# Altium schematic sheet FPGA_MISC.SchDoc(FPGA_MISC)
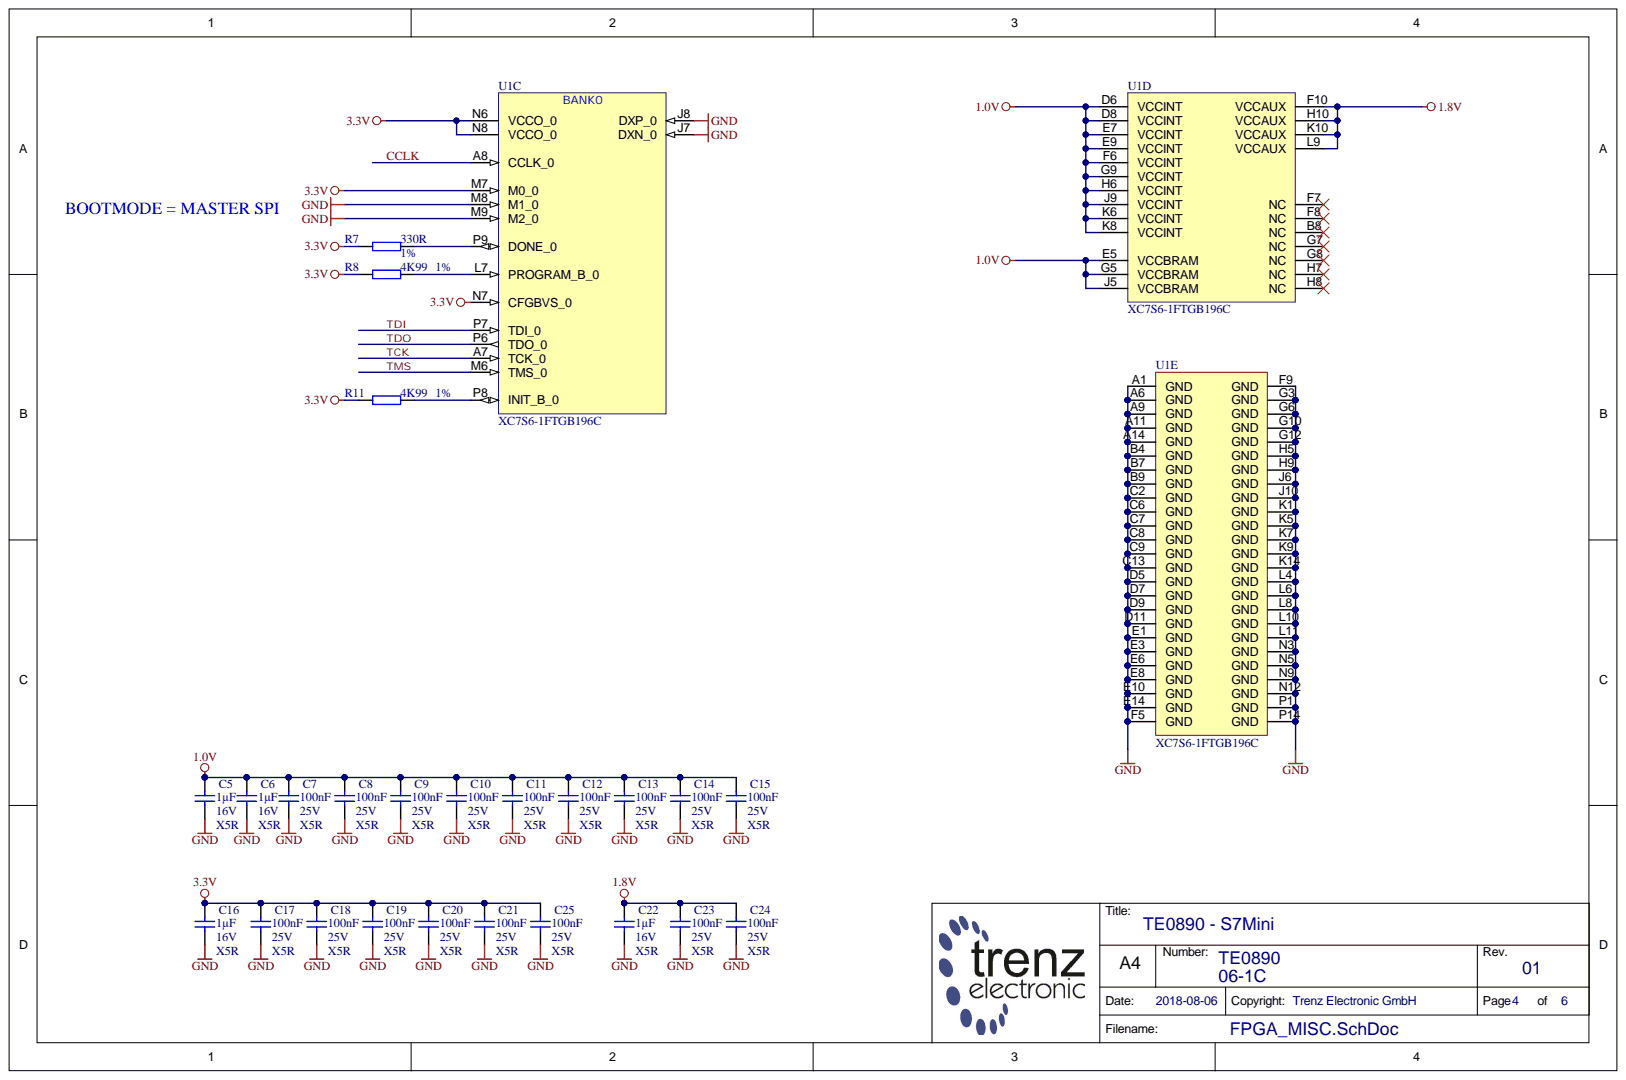
[diagram p0: FPGA_MISC.SchDoc]

## Parts
C5  1µF  Technology: X5R  Voltage: 16V  [CAPC1005X55N]
C6  1µF  Technology: X5R  Voltage: 16V  [CAPC1005X55N]
C7  100nF  Technology: X5R  Voltage: 25V  [CAPC1005X55N]
C8  100nF  Technology: X5R  Voltage: 25V  [CAPC1005X55N]
C9  100nF  Technology: X5R  Voltage: 25V  [CAPC1005X55N]
C10  100nF  Technology: X5R  Voltage: 25V  [CAPC1005X55N]
C11  100nF  Technology: X5R  Voltage: 25V  [CAPC1005X55N]
C12  100nF  Technology: X5R  Voltage: 25V  [CAPC1005X55N]
C13  100nF  Technology: X5R  Voltage: 25V  [CAPC1005X55N]
C14  100nF  Technology: X5R  Voltage: 25V  [CAPC1005X55N]
C15  100nF  Technology: X5R  Voltage: 25V  [CAPC1005X55N]
C16  1µF  Technology: X5R  Voltage: 16V  [CAPC1005X55N]
C17  100nF  Technology: X5R  Voltage: 25V  [CAPC1005X55N]
C18  100nF  Technology: X5R  Voltage: 25V  [CAPC1005X55N]
C19  100nF  Technology: X5R  Voltage: 25V  [CAPC1005X55N]
C20  100nF  Technology: X5R  Voltage: 25V  [CAPC1005X55N]
C21  100nF  Technology: X5R  Voltage: 25V  [CAPC1005X55N]
C22  1µF  Technology: X5R  Voltage: 16V  [CAPC1005X55N]
C23  100nF  Technology: X5R  Voltage: 25V  [CAPC1005X55N]
C24  100nF  Technology: X5R  Voltage: 25V  [CAPC1005X55N]
C25  100nF  Technology: X5R  Voltage: 25V  [CAPC1005X55N]
R7  330R  Tolerance: 1%  [RESC1005X40N]
R8  4K99  [RESC1005X40N]
R11  4K99  [RESC1005X40N]
U1  XC7S6-1FTGB196C  [BGA196C100P14X14_1500X1500X155B50N]  (parts C,D,E)

## Nets
1.0V: C5.1 C6.1 C7.1 C8.1 C9.1 C10.1 C11.1 C12.1 C13.1 C14.1 C15.1 U1.D6(VCCINT) U1.D8(VCCINT) U1.E5(VCCBRAM) U1.E7(VCCINT) U1.E9(VCCINT) U1.F6(VCCINT) U1.G5(VCCBRAM) U1.G9(VCCINT) U1.H6(VCCINT) U1.J5(VCCBRAM) U1.J9(VCCINT) U1.K6(VCCINT) U1.K8(VCCINT)
1.8V: C22.1 C23.1 C24.1 U1.F10(VCCAUX) U1.H10(VCCAUX) U1.K10(VCCAUX) U1.L9(VCCAUX)
3.3V: C16.1 C17.1 C18.1 C19.1 C20.1 C21.1 C25.1 R7.1 R8.1 R11.1 U1.M7(M0_0) U1.N6(VCCO_0) U1.N7(CFGBVS_0) U1.N8(VCCO_0)
GND: C5.2 C6.2 C7.2 C8.2 C9.2 C10.2 C11.2 C12.2 C13.2 C14.2 C15.2 C16.2 C17.2 C18.2 C19.2 C20.2 C21.2 C22.2 C23.2 C24.2 C25.2 U1.A1(GND) U1.A6(GND) U1.A9(GND) U1.A11(GND) U1.A14(GND) U1.B4(GND) U1.B7(GND) U1.B9(GND) U1.C2(GND) U1.C6(GND) U1.C7(GND) U1.C8(GND) U1.C9(GND) U1.C13(GND) U1.D5(GND) U1.D7(GND) U1.D9(GND) U1.D11(GND) U1.E1(GND) U1.E3(GND) U1.E6(GND) U1.E8(GND) U1.E10(GND) U1.E14(GND) U1.F5(GND) U1.F9(GND) U1.G3(GND) U1.G6(GND) U1.G10(GND) U1.G12(GND) U1.H5(GND) U1.H9(GND) U1.J6(GND) U1.J7(DXN_0) U1.J8(DXP_0) U1.J10(GND) U1.K1(GND) U1.K5(GND) U1.K7(GND) U1.K9(GND) U1.K14(GND) U1.L4(GND) U1.L6(GND) U1.L8(GND) U1.L10(GND) U1.L11(GND) U1.M8(M1_0) U1.M9(M2_0) U1.N3(GND) U1.N5(GND) U1.N9(GND) U1.N12(GND) U1.P1(GND) U1.P14(GND)
CCLK: U1.A8(CCLK_0)
TCK: U1.A7(TCK_0)
TDI: U1.P7(TDI_0)
TDO: U1.P6(TDO_0)
TMS: U1.M6(TMS_0)
NetR7_2: R7.2 U1.P9(DONE_0)
NetR8_2: R8.2 U1.L7(PROGRAM_B_0)
NetR11_2: R11.2 U1.P8(INIT_B_0)

Not connected: U1 B8(NC) F7(NC) F8(NC) G7(NC) G8(NC) H7(NC) H8(NC)

## Library data of the parts
C5 C6 C16 C22 = 26579: KON 1µF 16V X5R 10% SMD BF 1005 (0402); Database Table Name: C_1005_0402; Mixed: SMT; Temperature-Range: -55/+85; Value: 1u; AN: 26579; Case: 1005 (0402); Component Kind: Standard; Library Name: TE.DbLib; Tolerance: 10%
C7-C15 C17-C21 C23-C25 = 22472: KON 100nF 25V X5R ±10% SMD BF 1005 (0402); Database Table Name: C_1005_0402; Mixed: SMT; Preferred: Yes; Temperature-Range: -55/+85; Value: 100n; AN: 22472; Case: 1005 (0402); Component Kind: Standard; Library Name: TE.DbLib
R7 = 22762: WID SMD 330R 1% 0,063W  BF 1005 (0402); Database Table Name: R_1005_0402; H-ART: RC0402FR-13330RL; Mixed: SMT; Preferred: Yes; Value: 330; AN: 22762; Case: 1005 (0402); Component Kind: Standard; Library Name: TE.DbLib; Technology: TK100
R8 R11 = 22754: WID SMD 4K99 1% 0,063W; Database Table Name: R_1005_0402; H-ART: RC0402FR-074K99L; Mixed: SMT; Preferred: Yes; Value: 4.99K; AN: 22754; Case: 1005 (0402); Component Kind: Standard; Library Name: TE.DbLib; Technology: TK100; Tolerance: 1%
U1 = 29111: Database Table Name: PROG_LOGIC; Mixed: SMT; Temperature-Range: 0/+85; AN: 29111; Case: FTGB196; Component Kind: Standard; Description: XC7S6-1FTGB196C; H-ART: XC7S6-1FTGB196C; Library Name: TE.DbLib; HelpURL: B:\AD\adlib\datasheet\XC7
